# Altium schematic sheet Power.SchDoc
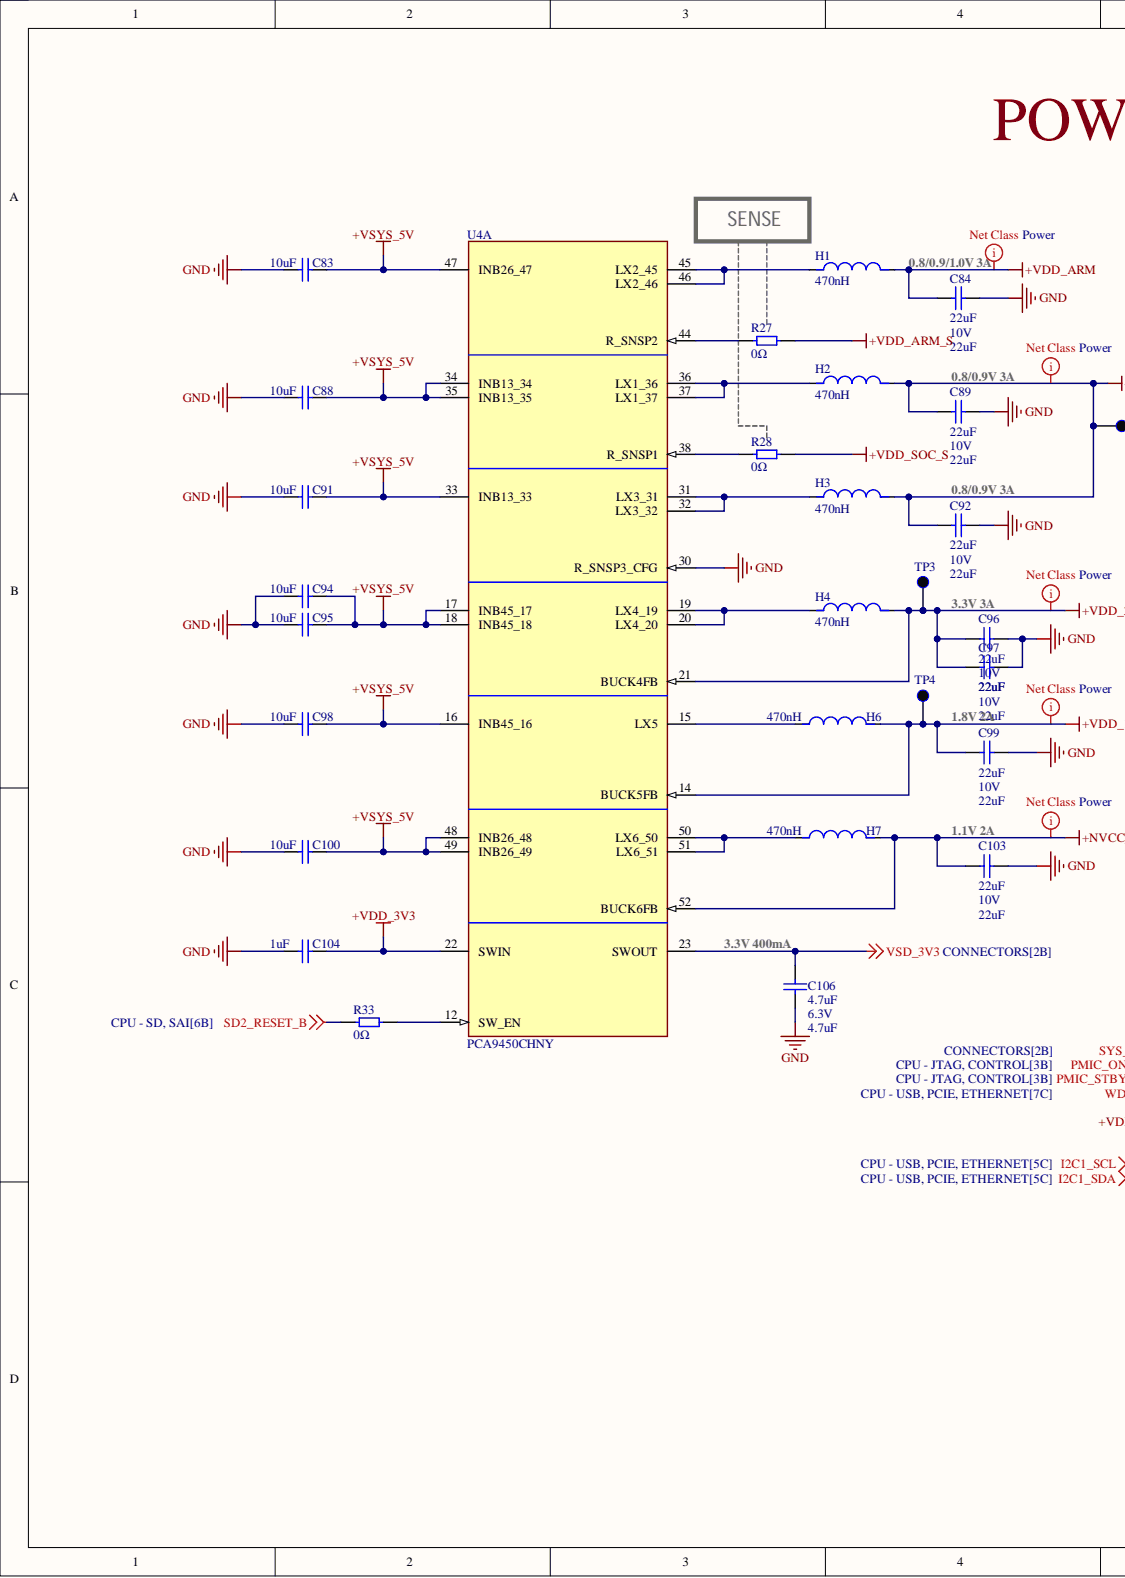
[diagram p0: Power.SchDoc, left]
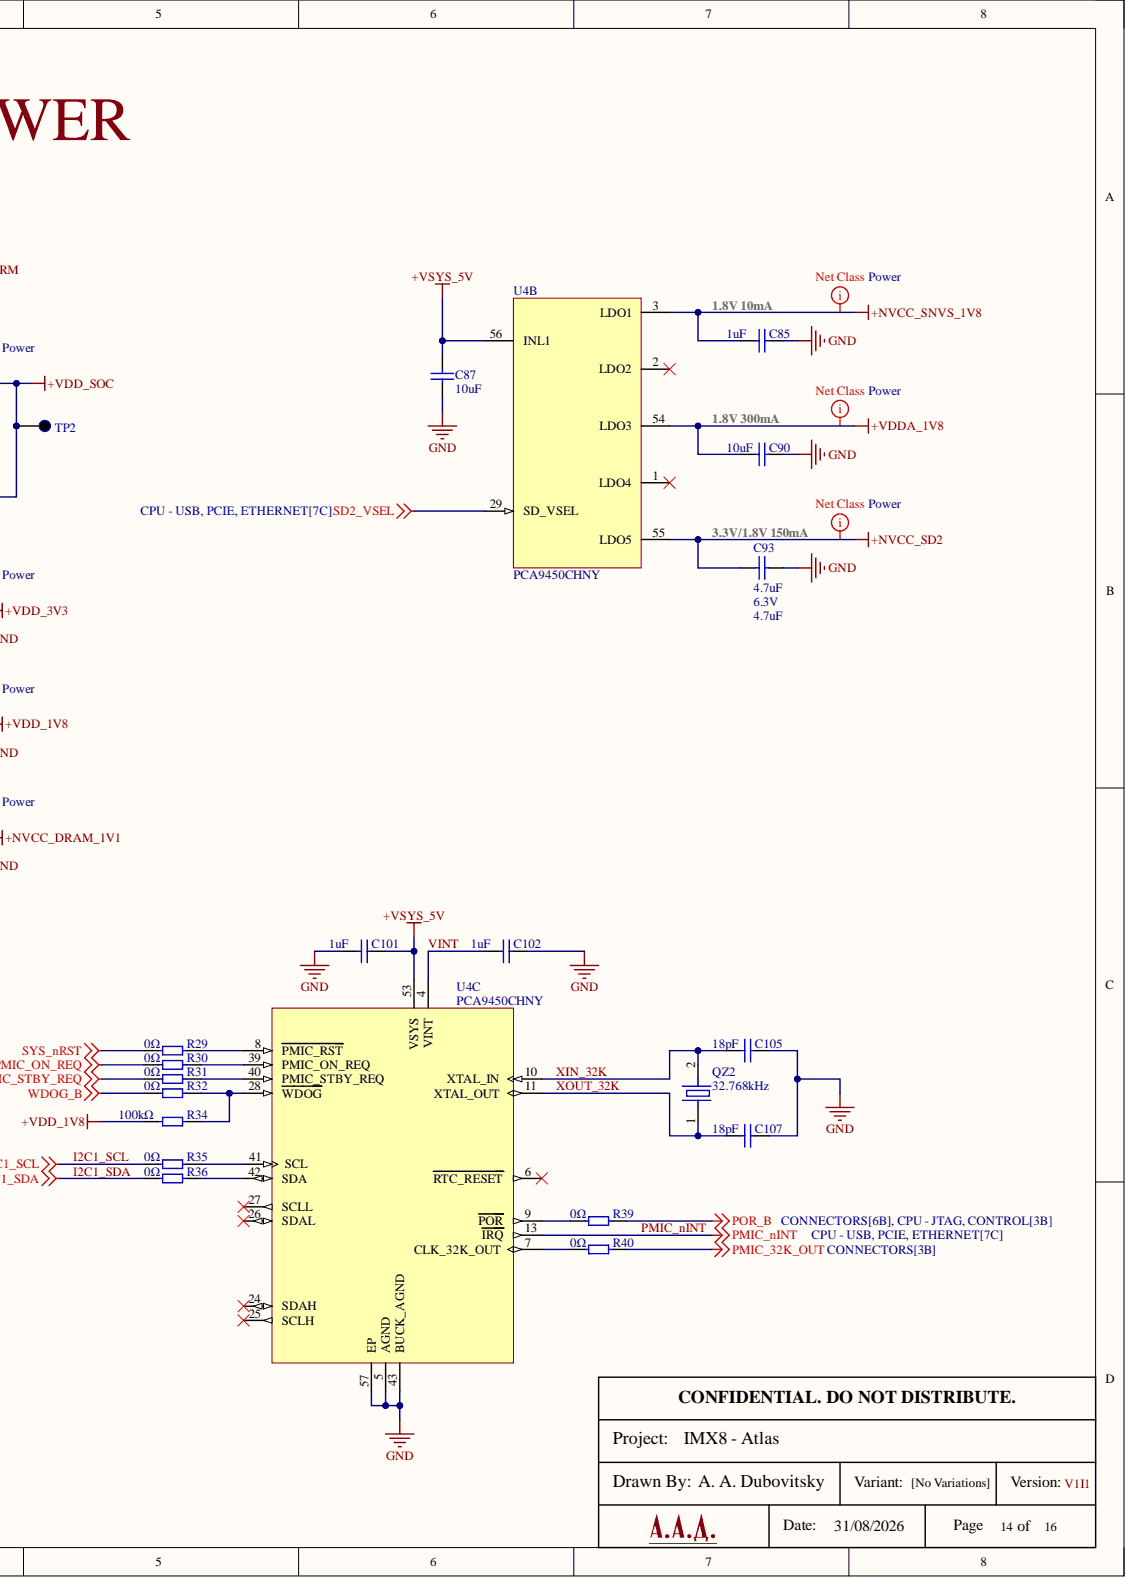
[diagram p1: Power.SchDoc, right]

## Parts
C83  10uF  [CAP 0402_1005]
C84  22uF  V: 10V  [CAP 0603_1608]
C85  1uF  [CAP 0201_0603 MINI]
C87  10uF  [CAP 0402_1005]
C88  10uF  [CAP 0402_1005]
C89  22uF  V: 10V  [CAP 0603_1608]
C90  10uF  [CAP 0402_1005]
C91  10uF  [CAP 0402_1005]
C92  22uF  V: 10V  [CAP 0603_1608]
C93  4.7uF  V: 6.3V  [CAP 0402_1005]
C94  10uF  [CAP 0402_1005]
C95  10uF  [CAP 0402_1005]
C96  22uF  [CAP 0603_1608]
C97  22uF  V: 10V  [CAP 0603_1608]
C98  10uF  [CAP 0402_1005]
C99  22uF  V: 10V  [CAP 0603_1608]
C100  10uF  [CAP 0402_1005]
C101  1uF  [CAP 0201_0603 MINI]
C102  1uF  [CAP 0201_0603 MINI]
C103  22uF  V: 10V  [CAP 0603_1608]
C104  1uF  [CAP 0201_0603 MINI]
C105  18pF  [CAP 0402_1005]
C106  4.7uF  V: 6.3V  [CAP 0402_1005]
C107  18pF  [CAP 0402_1005]
H1  470nH  [IND-SMD_L2.5-W2.0_WPN2520X]
H2  470nH  [IND-SMD_L2.5-W2.0_WPN2520X]
H3  470nH  [IND-SMD_L2.5-W2.0_WPN2520X]
H4  470nH  [IND-SMD_L2.5-W2.0_WPN2520X]
H6  470nH  [IND-SMD_L2.0-W1.6_FNR2016XXS]
H7  470nH  [IND-SMD_L2.0-W1.6_FNR2016XXS]
QZ2  32.768kHz  [CRYSTAL-SMD_L3.2-W1.5-1]
R27  0Ω  [RES 0201_0603]
R28  0Ω  [RES 0201_0603]
R29  0Ω  [RES 0201_0603]
R30  0Ω  [RES 0201_0603]
R31  0Ω  [RES 0201_0603]
R32  0Ω  [RES 0201_0603]
R33  0Ω  [RES 0201_0603]
R34  100kΩ  [RES 0402_1005]
R35  0Ω  [RES 0201_0603]
R36  0Ω  [RES 0201_0603]
R39  0Ω  [RES 0201_0603]
R40  0Ω  [RES 0201_0603]
TP2  Test Point  [Test Point Pad]  (value not drawn)
TP3  Test Point  [Test Point Pad]  (value not drawn)
TP4  Test Point  [Test Point Pad]  (value not drawn)
U4  PCA9450CHNY  [IC_PCA9450CHNY]  (parts A,B,C)

## Nets
+NVCC_DRAM_1V1: C103.2 H7.2 U4.52(BUCK6FB)
+NVCC_SD2: C93.1 U4.55(LDO5)
+NVCC_SNVS_1V8: C85.1 U4.3(LDO1)
+VDD_1V8: C99.2 H6.2 R34.2 TP4.1 U4.14(BUCK5FB)
+VDD_3V3: C96.2 C97.2 C104.2 H4.2 TP3.1 U4.21(BUCK4FB) U4.22(SWIN)
+VDD_ARM: C84.2 H1.2
+VDD_ARM_S: R27.1
+VDD_SOC: C89.2 C92.2 H2.2 H3.2 TP2.1
+VDD_SOC_S: R28.1
+VDDA_1V8: C90.2 U4.54(LDO3)
+VSYS_5V: C83.2 C87.2 C88.2 C91.2 C94.2 C95.2 C98.2 C100.2 C101.2 U4.16(INB45_16) U4.17(INB45_17) U4.18(INB45_18) U4.33(INB13_33) U4.34(INB13_34) U4.35(INB13_35) U4.47(INB26_47) U4.48(INB26_48) U4.49(INB26_49) U4.53(VSYS) U4.56(INL1)
GND: C83.1 C84.1 C85.2 C87.1 C88.1 C89.1 C90.1 C91.1 C92.1 C93.2 C94.1 C95.1 C96.1 C97.1 C98.1 C99.1 C100.1 C101.1 C102.2 C103.1 C104.1 C105.2 C106.1 C107.2 U4.5(AGND) U4.30(R_SNSP3_CFG) U4.43(BUCK_AGND) U4.57(EP)
PMIC_32K_OUT: R40.1
PMIC_ON_REQ: R30.2
PMIC_STBY_REQ: R31.2
POR_B: R39.1
SD2_RESET_B: R33.2
SD2_VSEL: U4.29(SD_VSEL)
SYS_nRST: R29.2
VSD_3V3: C106.2 U4.23(SWOUT)
WDOG_B: R32.2
I2C1_SCL: R35.2
I2C1_SDA: R36.2
PMIC_nINT: U4.13(IRQ)
VINT: C102.1 U4.4(VINT)
XIN_32K: C105.1 QZ2.2 U4.10(XTAL_IN)
XOUT_32K: C107.1 QZ2.1 U4.11(XTAL_OUT)
NetH1_1: H1.1 U4.45(LX2_45) U4.46(LX2_46)
NetH2_1: H2.1 U4.36(LX1_36) U4.37(LX1_37)
NetH3_1: H3.1 U4.31(LX3_31) U4.32(LX3_32)
NetH4_1: H4.1 U4.19(LX4_19) U4.20(LX4_20)
NetH6_1: H6.1 U4.15(LX5)
NetH7_1: H7.1 U4.50(LX6_50) U4.51(LX6_51)
NetR27_2: R27.2 U4.44(R_SNSP2)
NetR28_2: R28.2 U4.38(R_SNSP1)
NetR29_1: R29.1 U4.8(PMIC_RST)
NetR30_1: R30.1 U4.39(PMIC_ON_REQ)
NetR31_1: R31.1 U4.40(PMIC_STBY_REQ)
NetR32_1: R32.1 R34.1 U4.28(WDOG)
NetR33_1: R33.1 U4.12(SW_EN)
NetR35_1: R35.1 U4.41(SCL)
NetR36_1: R36.1 U4.42(SDA)
NetR39_2: R39.2 U4.9(POR)
NetR40_2: R40.2 U4.7(CLK_32K_OUT)

Not connected: U4 1(LDO4) 2(LDO2) 6(RTC_RESET) 24(SDAH) 25(SCLH) 26(SDAL) 27(SCLL)

## Library data of the parts
C83 C87 C88 C90 C91 C94 C95 C98 C100 = C2846677: Cap. 10uF 10V ±20% 0402; Component Kind: Standard; Library Name: Atlas - Symbols.SchLib
C84 C89 C92 C96 C97 C99 C103 = CL10A226MP8NUNE: Cap. 22uF 10V ±20%; C: 22uF; Component Kind: Standard; Library Name: Atlas - Symbols.SchLib
  C96: V: 10V
C85 C101 C102 C104 = C6119758: Cap. 1uF 10V ±20% 0201; Component Kind: Standard; Library Name: Atlas - Symbols.SchLib
C93 C106 = GRM155R60J475ME87D: Cap. 4.7uF 6.3V ±20%; C: 4.7uF; Component Kind: Standard; Library Name: Atlas - Symbols.SchLib
C105 C107 = 0402CG180J500NT: Cap.  18pF 50V ±5%; Component Kind: Standard; Parameter 1: 18pF; Library Name: Atlas - Symbols.SchLib
H1-H4 = C279796: Ind 29mΩ 3.45A 4.9A 470nH ±20% 1008; Component Kind: Standard; Library Name: Atlas - Symbols.SchLib
H6 H7 = C97021: Ind. 28mΩ 3.6A 4.5A 470nH ±20% 0806; Component Kind: Standard; Library Name: Atlas - Symbols.SchLib
QZ2 = C95361: Crystal Oscillator 32.768kHz 6pF 70kΩ; Component Kind: Standard; Library Name: Atlas - Symbols.SchLib
R27-R33 R35 R36 R39 R40 = C106227: Res. 0Ω 25V 50mW ±1% 0201; Component Kind: Standard; Library Name: Atlas - Symbols.SchLib
R34 = C25741: Res. 100kΩ 50V 62.5mW ±1% 0402; Component Kind: Standard; Library Name: Atlas - Symbols.SchLib
U4 = PCA9450CHNY: Application Processors 2.7V to 5.5V 56-Pin HVQFN EP T/R; MF: NXP Semiconductors; Check_prices: https://www.snapeda.com/parts/PCA9450CHNY/NXP+Semiconductors/view-part/?ref=eda; Component Kind: Standard; MP: PCA9450CHNY; Package: VFQFN-56 NXP USA Inc.; SnapEDA_Link: https://www.snapeda.com/parts/PCA9450CHNY/NXP+Semiconductors/view-part/?ref=snap; Library Name: Atlas - Symbols.SchLib
TP2-TP4 = Test Point: Component Kind: Standard; Library Name: Atlas - Symbols.SchLib
